# Altium schematic sheet Debug_Pimoroni.SchDoc
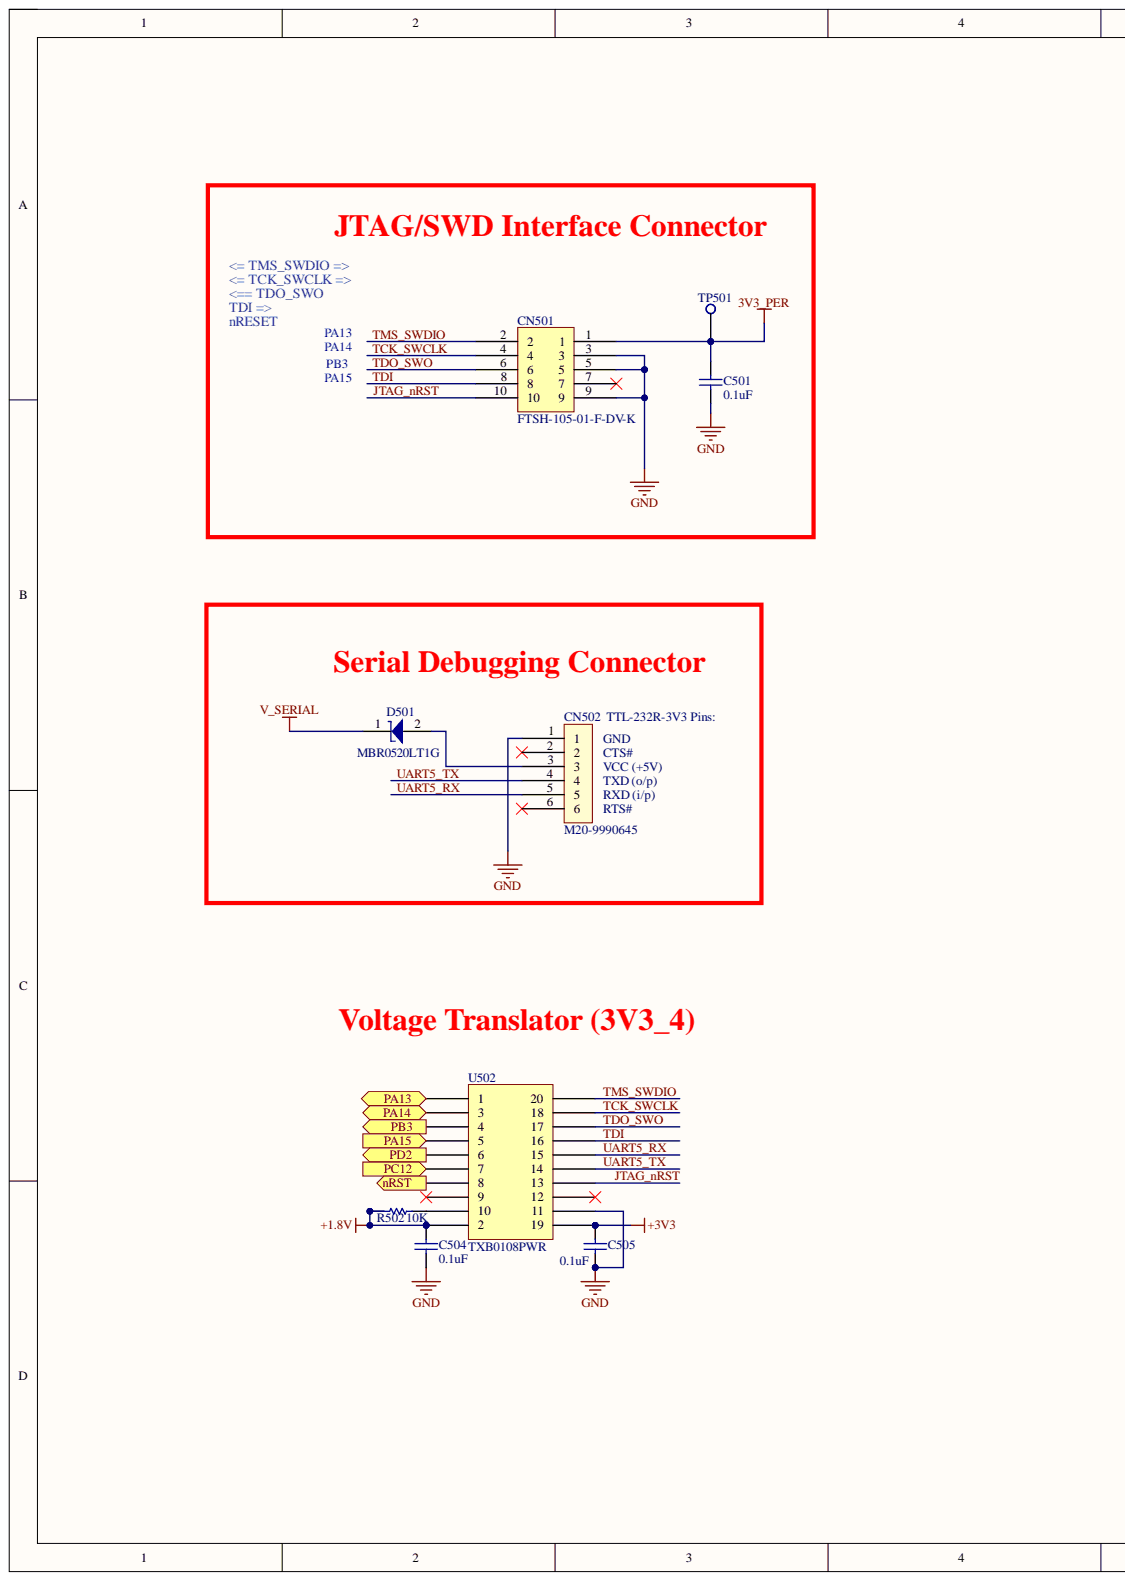
[diagram p0: Debug_Pimoroni.SchDoc, left]
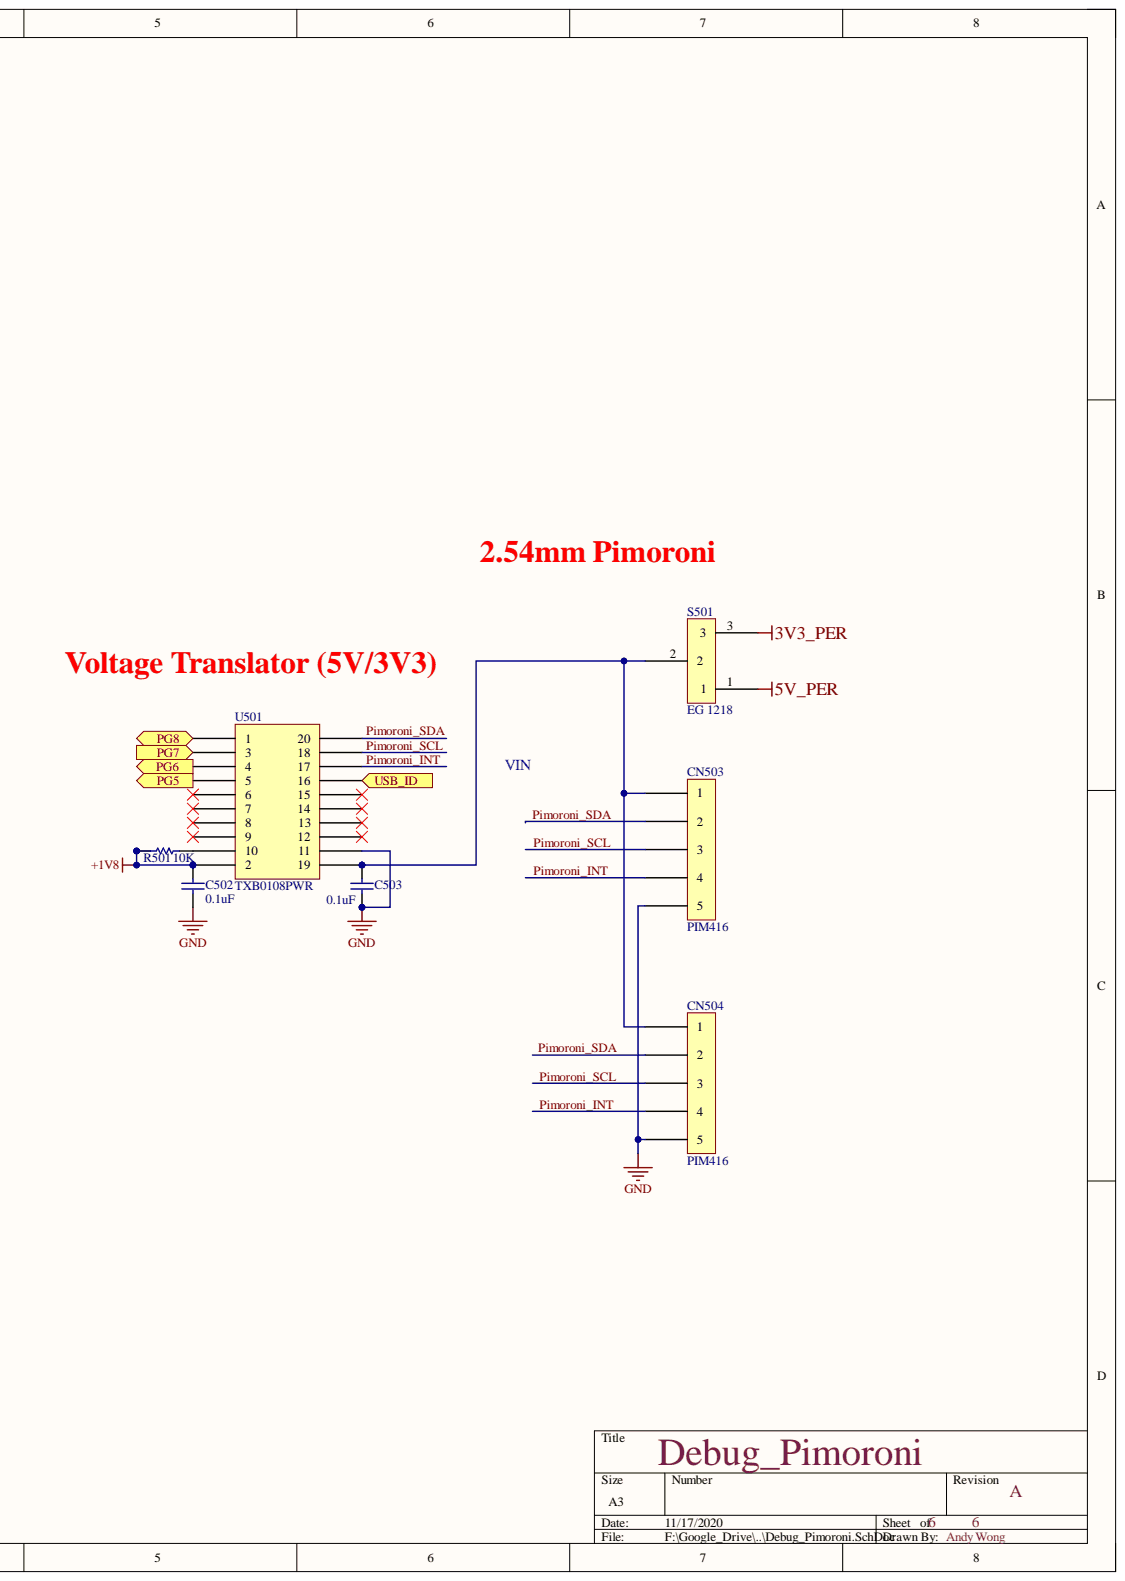
[diagram p1: Debug_Pimoroni.SchDoc, right]

## Parts
C501  0.1uF  [Cap 0402]
C502  0.1uF  [Cap 0402]
C503  0.1uF  [Cap 0402]
C504  0.1uF  [Cap 0402]
C505  0.1uF  [Cap 0402]
CN501  FTSH-105-01-F-DV-K  [FTSH-105-01-F-DV-K]
CN502  M20-9990645  [M20-9990645]
CN503  PIM416  [PIM416]
CN504  PIM416  [PIM416]
D501  MBR0520LT1G  [MBR0520LT1G]
R501  10K  [Res 0402]
R502  10K  [Res 0402]
S501  EG 1218  [EG_1218]
TP501  Test Point  [TP]  (value not drawn)
U501  TXB0108PWR  [TXB0108PWR]
U502  TXB0108PWR  [TXB0108PWR]

## Ports
nRST, PA13, PA14, PA15, PB3, PC12, PD2, PG5, PG6, PG7, PG8, USB_ID

## Nets
3V3_PER: C501.1 C505.2 CN501.1 S501.3 TP501.1 U502.19
5V_PER: S501.1
+1.8V: C504.1 R502.2 U502.2
+1V8: C502.1 R501.2 U501.2
GND: C501.2 C502.2 C503.1 C504.2 C505.1 CN501.3 CN501.5 CN501.9 CN502.1 CN503.5 CN504.5 U501.11 U502.11
V_SERIAL: D501.1
JTAG_nRST: CN501.10 U502.13
nRST: U502.8 ; port nRST
PA13: U502.1 ; port PA13
PA14: U502.3 ; port PA14
PA15: U502.5 ; port PA15
PB3: U502.4 ; port PB3
PC12: U502.7 ; port PC12
PD2: U502.6 ; port PD2
PG5: U501.5 ; port PG5
PG6: U501.4 ; port PG6
PG7: U501.3 ; port PG7
PG8: U501.1 ; port PG8
Pimoroni_INT: CN503.4 CN504.4 U501.17
Pimoroni_SCL: CN503.3 CN504.3 U501.18
Pimoroni_SDA: CN503.2 CN504.2 U501.20
TCK_SWCLK: CN501.4 U502.18
TDI: CN501.8 U502.16
TDO_SWO: CN501.6 U502.17
TMS_SWDIO: CN501.2 U502.20
UART5_RX: CN502.5 U502.15
UART5_TX: CN502.4 U502.14
USB_ID: U501.16 ; port USB_ID
NetC503_2: C503.2 CN503.1 CN504.1 S501.2 U501.19
NetCN502_3: CN502.3 D501.2
NetR501_1: R501.1 U501.10
NetR502_1: R502.1 U502.10

Not connected: CN501 7; CN502 2 6; U501 6 7 8 9 12 13 14 15; U502 9 12

## Library data of the parts
C501-C505 = Cap: GRM155R71C104KA88, 0402; Component Kind: Standard; LatestRevisionDate: 17-Jul-2002; LatestRevisionNote: Re-released for DXP Platform.; Library Name: Miscellaneous Devices.IntLib; PackageDescription: Radial Cap, Thru-Hole; 2 Leads; 0.3 in Pin Spacing; PackageReference: RAD-0.3; Published: 8-Jun-2000; Signal Integrity: Cap; Simulation: CAP; Publisher: Altium Limited
CN501 = JTAG/SWD: JTAG/SWD connectors; Component Kind: Standard; Library Name: MA_Eva_Board.SchLib
CN502 = Serial_Debug: Serial Debug Connector; Component Kind: Standard; Library Name: PCB_Connectors.SchLib
CN503 CN504 = PIM416: 5 pin edge connector for pimorini; Component Kind: Standard; Library Name: MA_Eva_Board.SchLib
R501 R502 = Res1: ERA-2AED103X, 0402; Component Kind: Standard; LatestRevisionDate: 17-Jul-2002; LatestRevisionNote: Re-released for DXP Platform.; Library Name: Miscellaneous Devices.IntLib; PackageDescription: Axial Device, Thru-Hole; 2 Leads; 0.3 in Pin Spacing; PackageReference: AXIAL-0.3; Published: 8-Jun-2000; Signal Integrity: Res; Simulation: RESISTOR; Publisher: Altium Limited
S501 = EG _1218: E-Switch; Component Kind: Standard; Library Name: MA_Eva_Board.SchLib
U501 U502 = TXB0108PWR: 8-Bit Bidirectional Voltage-Level Translator w; Component Kind: Standard; Library Name: MA_Eva_Board.SchLib
D501 = MBR0520LT1G: Component Kind: Standard; Description: MBR0520LT1G; Library Name: MA_Eva_Board.SchLib
TP501 = Test Point: Component Kind: Standard; Description: Test Point; Library Name: MA_Eva_Board.SchLib
